# Altium schematic sheet Sheet1.SchDoc
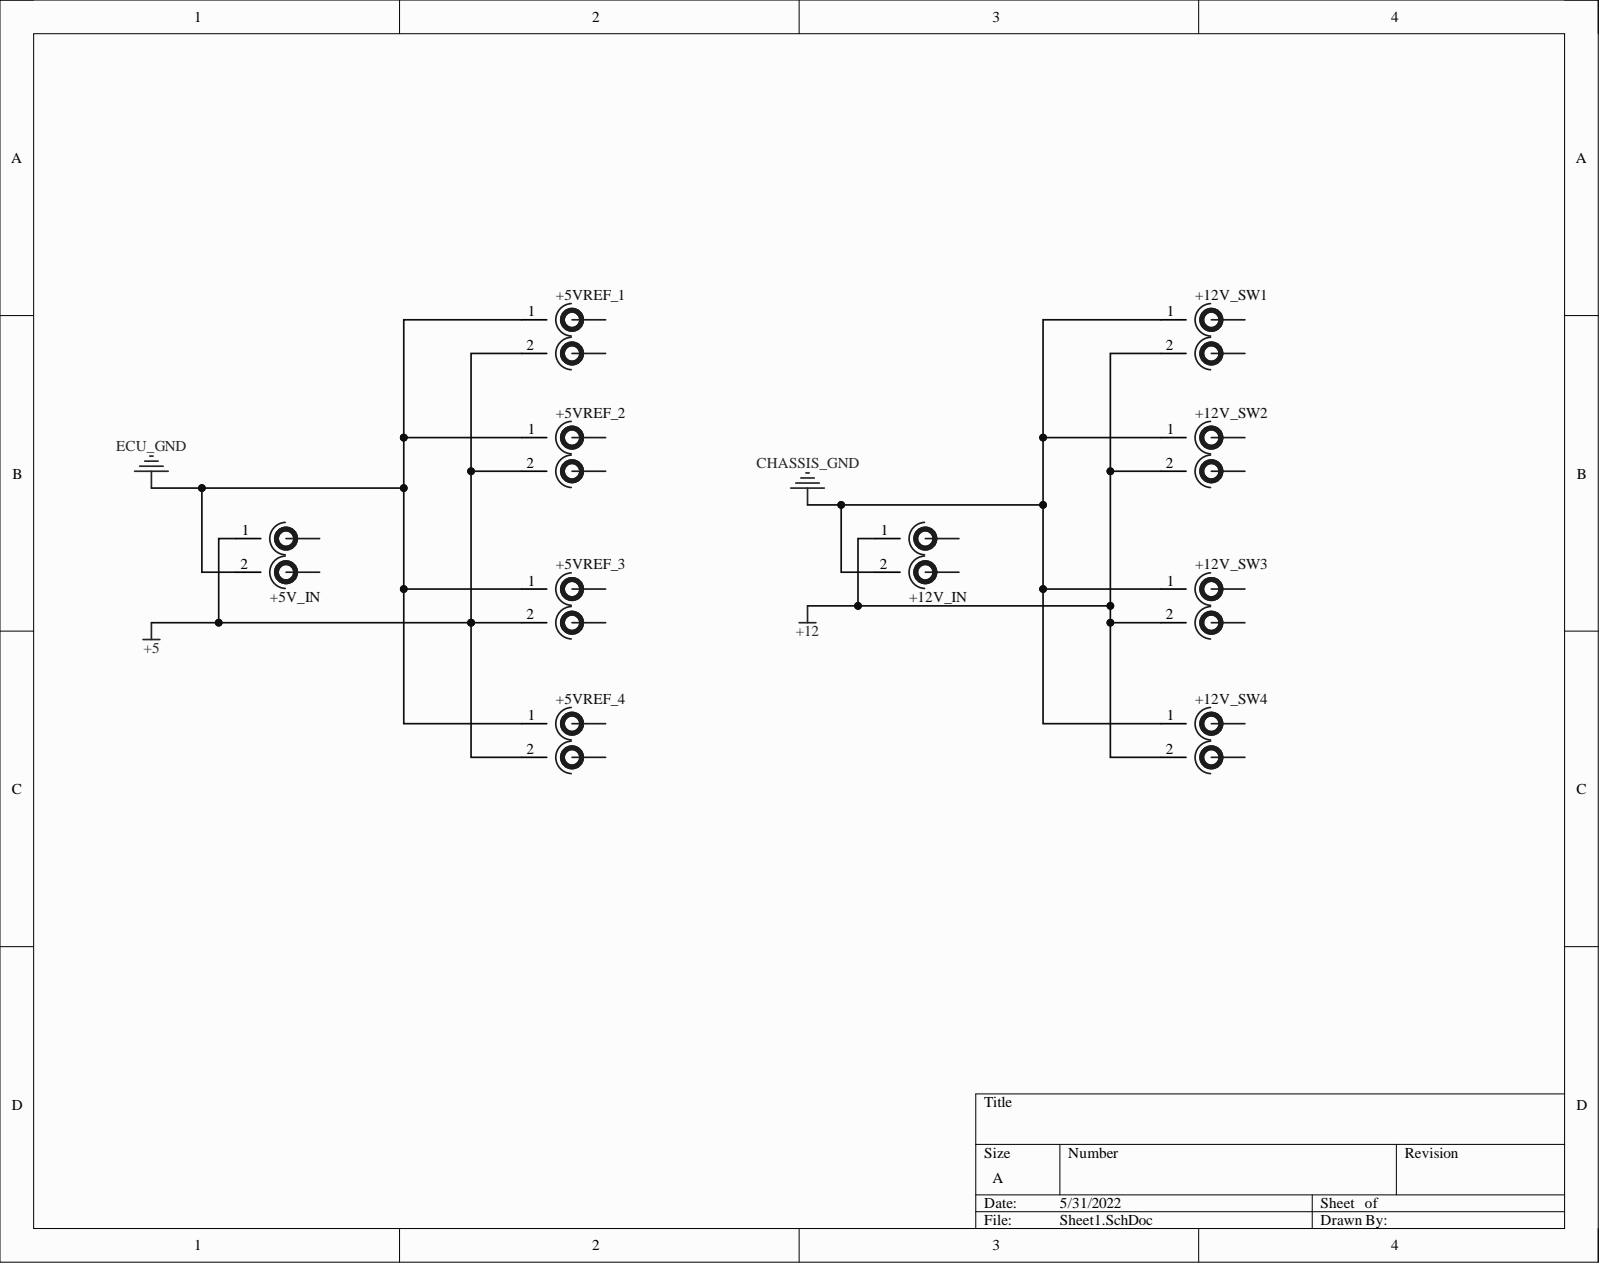
[diagram p0: Sheet1.SchDoc]

## Parts
+5V_IN  Degson DG332K-5.0-02P-12-00A(H)  [PCB-75j7l7ipw09x47irv7cu-2]  (value not drawn)
+5VREF_1  Degson DG332K-5.0-02P-12-00A(H)  [PCB-75j7l7ipw09x47irv7cu-2]  (value not drawn)
+5VREF_2  Degson DG332K-5.0-02P-12-00A(H)  [PCB-75j7l7ipw09x47irv7cu-2]  (value not drawn)
+5VREF_3  Degson DG332K-5.0-02P-12-00A(H)  [PCB-75j7l7ipw09x47irv7cu-2]  (value not drawn)
+5VREF_4  Degson DG332K-5.0-02P-12-00A(H)  [PCB-75j7l7ipw09x47irv7cu-2]  (value not drawn)
+12V_IN  Degson DG332K-5.0-02P-12-00A(H)  [PCB-75j7l7ipw09x47irv7cu-2]  (value not drawn)
+12V_SW1  Degson DG332K-5.0-02P-12-00A(H)  [PCB-75j7l7ipw09x47irv7cu-2]  (value not drawn)
+12V_SW2  Degson DG332K-5.0-02P-12-00A(H)  [PCB-75j7l7ipw09x47irv7cu-2]  (value not drawn)
+12V_SW3  Degson DG332K-5.0-02P-12-00A(H)  [PCB-75j7l7ipw09x47irv7cu-2]  (value not drawn)
+12V_SW4  Degson DG332K-5.0-02P-12-00A(H)  [PCB-75j7l7ipw09x47irv7cu-2]  (value not drawn)

## Nets
+5: +5V_IN.1 +5VREF_1.2 +5VREF_2.2 +5VREF_3.2 +5VREF_4.2
+12: +12V_IN.1 +12V_SW1.2 +12V_SW2.2 +12V_SW3.2 +12V_SW4.2
CHASSIS_GND: +12V_IN.2 +12V_SW1.1 +12V_SW2.1 +12V_SW3.1 +12V_SW4.1
ECU_GND: +5V_IN.2 +5VREF_1.1 +5VREF_2.1 +5VREF_3.1 +5VREF_4.1

## Library data of the parts
+5V_IN +5VREF_1-+5VREF_4 +12V_IN +12V_SW1-+12V_SW4 = CMP-292de1f1f4e4f6ea-1: Pluggable terminal block; terminal block; 5mm; angled 90°; 10A; Color: Blue; Component Kind: Standard; Contact Material: Brass; Contact Plating: Tin; Flammability Rating: UL 94 V-0; Library Name: My Personal Space; Manufacturer Part Number: DG332K-5.0-02P-12-00A(H); Octopart URL: http://octopart.com/dg332k-5.0-02p-12-00a%28h%29-degson-30865583; OctopartID: 292de1f1f4e4f6ea; Orientation: Right Angle
